# Altium schematic sheet sheet2.SchDoc
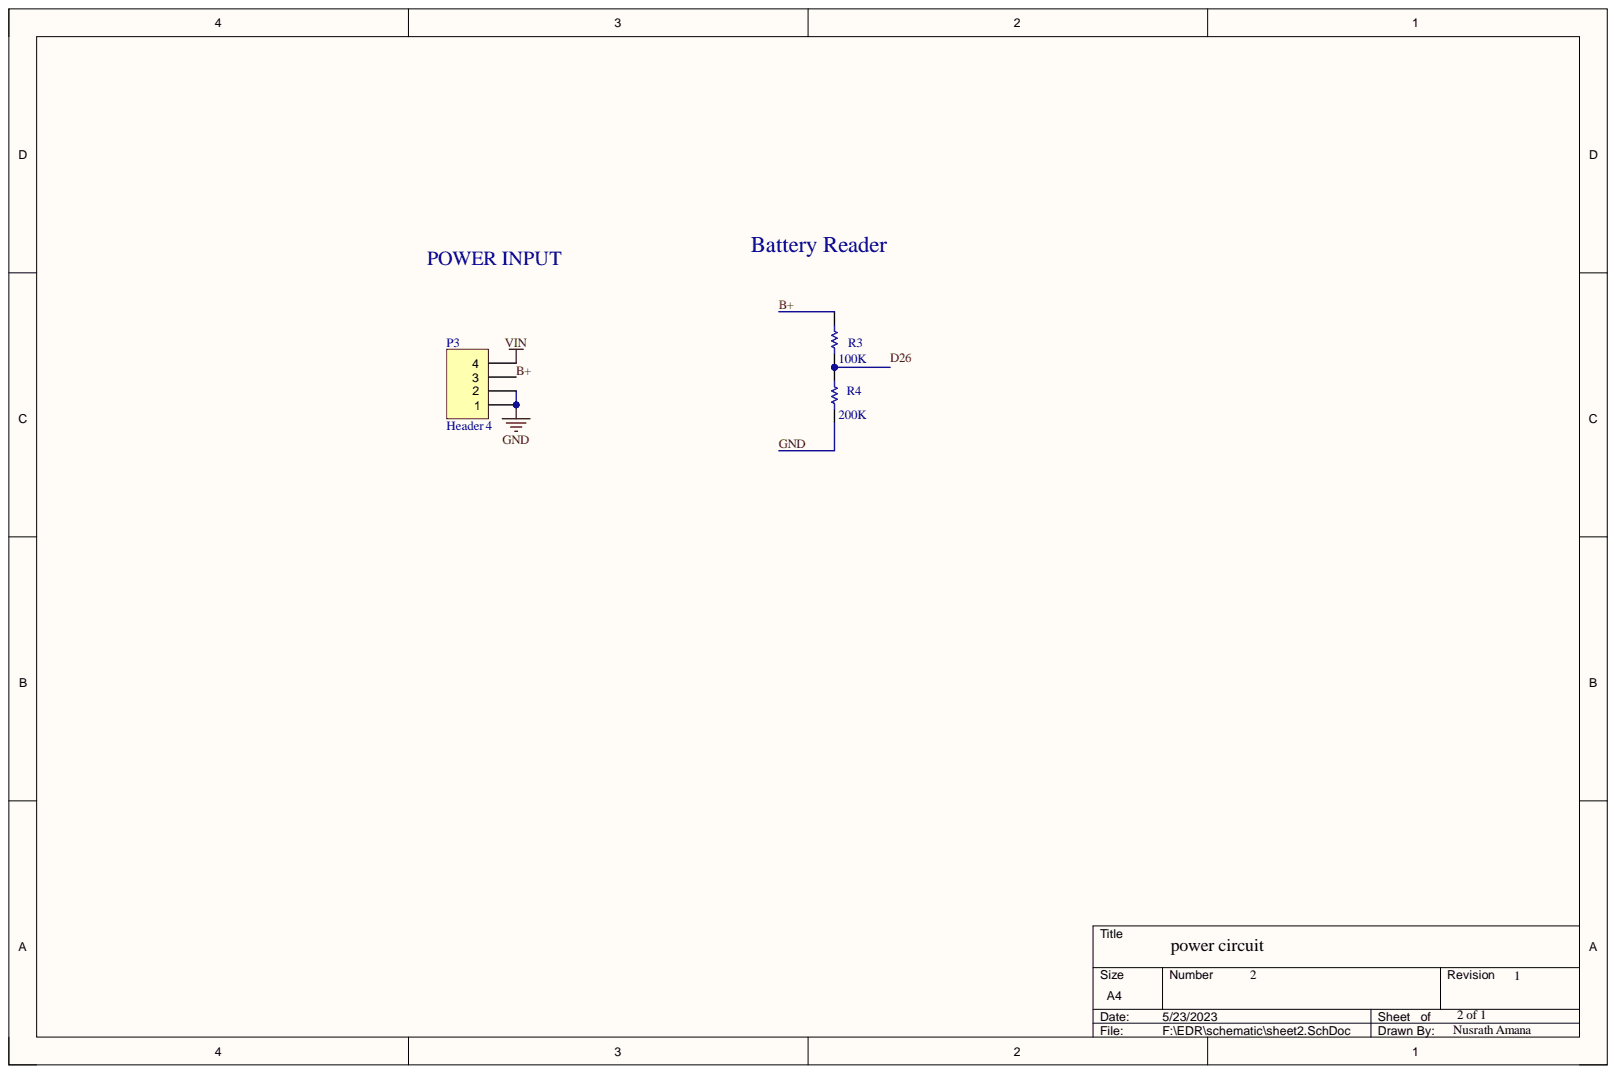
[diagram p0: sheet2.SchDoc]

## Parts
P3  Header 4  [HDR1X4]
R3  value 100K  [AXIAL-0.3]  (hidden comment: Res1)
R4  value 200K  [AXIAL-0.3]  (hidden comment: Res1)

## Nets
VIN: P3.4
B+: P3.3 R3.2
GND: P3.1 P3.2 R4.1
NetR3_1: R3.1 R4.2
D26:

## Library data of the parts
P3 = Header 4: Header, 4-Pin; Component Kind: Standard; LatestRevisionDate: 17-Jul-2002; LatestRevisionNote: Re-released for DXP Platform.; Library Name: Miscellaneous Connectors.IntLib; Signal Integrity: Connector; Publisher: Altium Limited
R3 R4 = Res1: Resistor; Component Kind: Standard; LatestRevisionDate: 17-Jul-2002; LatestRevisionNote: Re-released for DXP Platform.; Library Name: Miscellaneous Devices.IntLib; PackageDescription: Axial Device, Thru-Hole; 2 Leads; 0.3 in Pin Spacing; PackageReference: AXIAL-0.3; Published: 8-Jun-2000; Signal Integrity: Res; Simulation: RESISTOR; Publisher: Altium Limited
